# Altium schematic sheet Power Management.SchDoc("Power Management")
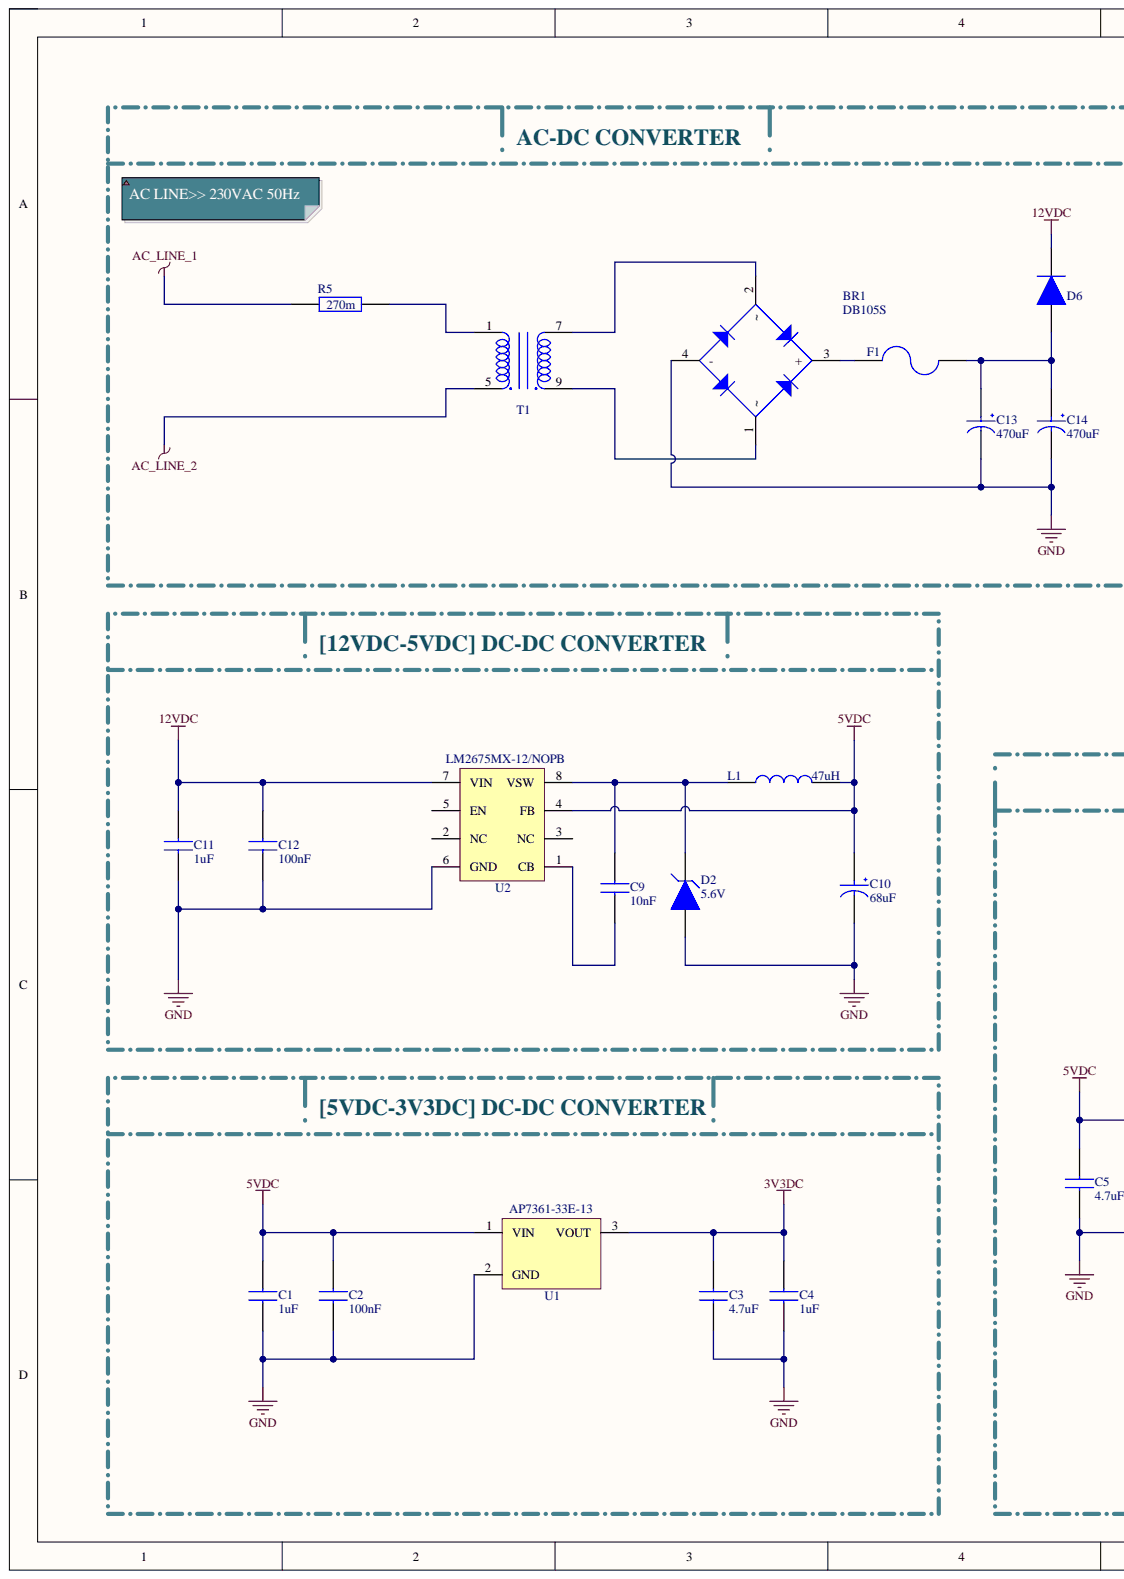
[diagram p0: Power Management.SchDoc, left]
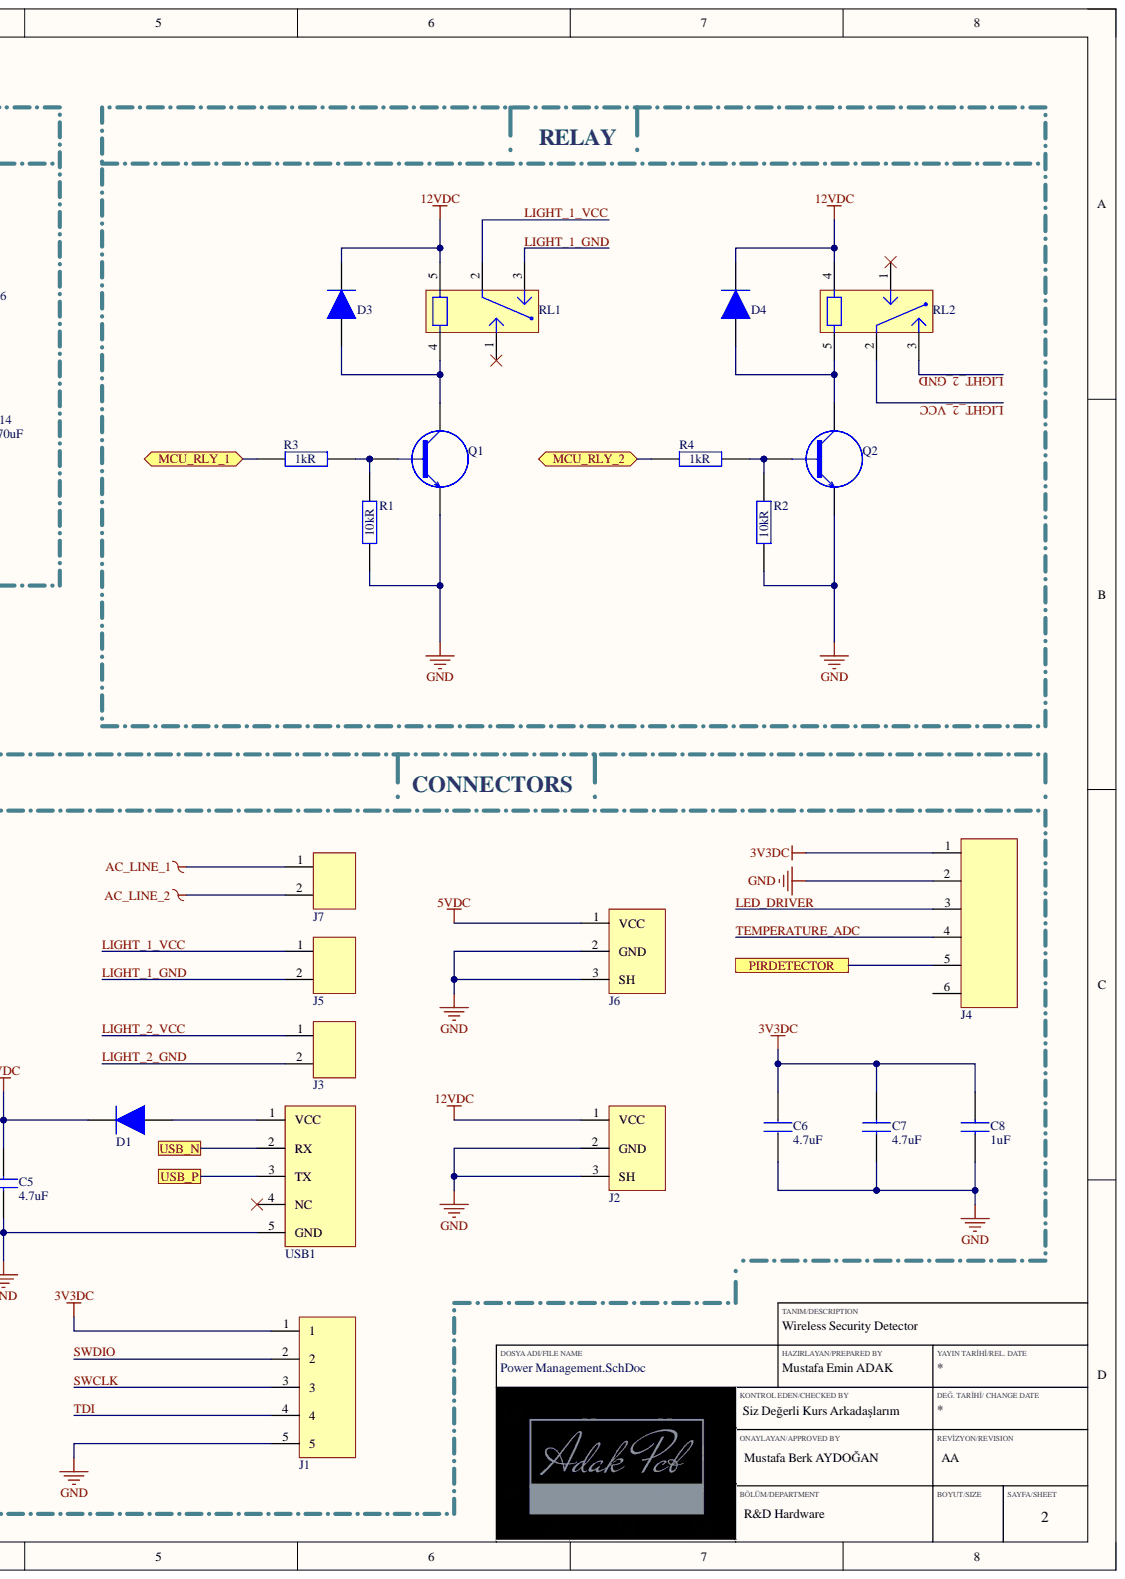
[diagram p1: Power Management.SchDoc, right]

## Parts
BR1  DB105S  [DB105S]
C1  Capacitance: 1uF  [CAP_0805]  (hidden comment: CL21B105KBFNNNE)
C2  Capacitance: 100nF  [CAP_0603]  (hidden comment: C0603C104K4RAC7867)
C3  Capacitance: 4.7uF  [CAP_0805]  (hidden comment: CL21A475KAQNNNE)
C4  Capacitance: 1uF  [CAP_0805]  (hidden comment: CL21B105KBFNNNE)
C5  Capacitance: 4.7uF  [CAP_0805]  (hidden comment: CL21A475KAQNNNE)
C6  Capacitance: 4.7uF  [CAP_0805]  (hidden comment: CL21A475KAQNNNE)
C7  Capacitance: 4.7uF  [CAP_0805]  (hidden comment: CL21A475KAQNNNE)
C8  Capacitance: 1uF  [CAP_0805]  (hidden comment: CL21B105KBFNNNE)
C9  Capacitance: 10nF  [CAP_0603]  (hidden comment: 0603B103K160CT)
C10  Capacitance: 68uF  [CAP_EEV/SERIES]  (hidden comment: EEV686M035A9HAA)
C11  Capacitance: 1uF  [CAP_0805]  (hidden comment: CL21B105KBFNNNE)
C12  Capacitance: 100nF  [CAP_0805]  (hidden comment: CL21B104KBCNNNC)
C13  Capacitance: 470uF  [CAP_RADIAL_D10_P5]  (hidden comment: ESH477M050AH4AA)
C14  Capacitance: 470uF  [CAP_RADIAL_D10_P5]  (hidden comment: ESH477M050AH4AA)
D1  1N4148WS  [SOD323F]  (value not drawn)
D2  Voltage Rating (DC): 5.6V  [SMB]  (hidden comment: 1SMB5919BT3G)
D3  1N4148WS  [SOD323F]  (value not drawn)
D4  1N4148WS  [SOD323F]  (value not drawn)
D6  1N4148WS  [SOD323F]  (value not drawn)
F1  3413.0216.22  [3413.0216.22]  (value not drawn)
J1  SMH-105-02-L-S  [PINHEADER1X5_RA]  (value not drawn)
J2  PJ-037AH-SMT-TR  [JACK]  (value not drawn)
J3  TBP01R1-508-02BE  [TBP01R1-508]  (value not drawn)
J4  64800611622  [64800611622]  (value not drawn)
J5  TBP01R1-508-02BE  [TBP01R1-508]  (value not drawn)
J6  PJ-037AH-SMT-TR  [JACK]  (value not drawn)
J7  TBP01R1-508-02BE  [TBP01R1-508]  (value not drawn)
L1  Inductance: 47uH  [IND_1212]  (hidden comment: 78438335470)
Q1  MMBT3904-7-F  [SOT-23F]  (value not drawn)
Q2  MMBT3904-7-F  [SOT-23F]  (value not drawn)
R1  Resistance: 10kR  [RES_0603]  (hidden comment: RC0603FR-0710KL)
R2  Resistance: 10kR  [RES_0603]  (hidden comment: RC0603FR-0710KL)
R3  Resistance: 1kR  [RES_0603]  (hidden comment: RC0603FR-071KL)
R4  Resistance: 1kR  [RES_0603]  (hidden comment: RC0603FR-071KL)
R5  Resistance: 270m  [RES_AXIAL_W]  (hidden comment: 5WD27)
RL1  ALQ112  [ALQ112]  (value not drawn)
RL2  ALQ112  [ALQ112]  (value not drawn)
T1  BV302S12010-ZU  [BV302S]  (value not drawn)
U1  AP7361-33E-13  [SOT223]
U2  LM2675MX-12/NOPB  [SOIC8]
USB1  10118192-0001LF  [MICRO_B_USB]  (value not drawn)

## Ports
MCU_RLY_1, MCU_RLY_2, PIRDETECTOR, USB_N, USB_P

## Nets
3V3DC: C3.2 C4.2 C6.2 C7.2 C8.2 J1.1 J4.1 U1.3
5VDC: C1.2 C2.2 C5.2 C10.1 D1.1 J6.1 L1.2 U1.1 U2.4(FB)
12VDC: C11.2 C12.2 D3.1 D4.1 D6.1 J2.1 RL1.5 RL2.4 U2.7(VIN)
AC_LINE_1: J7.1 R5.1
AC_LINE_2: J7.2 T1.5
GND: BR1.4(-) C1.1 C2.1 C3.1 C4.1 C5.1 C6.1 C7.1 C8.1 C10.2 C11.1 C12.1 C13.2 C14.2 D2.C J1.5 J2.2 J2.3 J4.2 J6.2 J6.3 Q1.2 Q2.2 R1.1 R2.1 U1.2 U2.6(GND) USB1.5(GND)
LED_DRIVER: J4.3
LIGHT_1_GND: J5.2 RL1.3
LIGHT_1_VCC: J5.1 RL1.2
LIGHT_2_GND: J3.2 RL2.3
LIGHT_2_VCC: J3.1 RL2.2
MCU_RLY_1: R3.1 ; port MCU_RLY_1
MCU_RLY_2: R4.1 ; port MCU_RLY_2
PIRDETECTOR: J4.5 ; port PIRDETECTOR
SWCLK: J1.3
SWDIO: J1.2
TDI: J1.4
TEMPERATURE_ADC: J4.4
USB_N: USB1.2(RX) ; port USB_N
USB_P: USB1.3(TX) ; port USB_P
NetBR1_1: BR1.1(~) T1.9
NetBR1_2: BR1.2(~) T1.7
NetBR1_3: BR1.3(+) F1.1
NetC9_1: C9.1 U2.1(CB)
NetC9_2: C9.2 D2.A L1.1 U2.8(VSW)
NetC13_1: C13.1 C14.1 D6.2 F1.2
NetD1_2: D1.2 USB1.1(VCC)
NetD3_2: D3.2 Q1.3 RL1.4
NetD4_2: D4.2 Q2.3 RL2.5
NetQ1_1: Q1.1 R1.2 R3.2
NetQ2_1: Q2.1 R2.2 R4.2
NetR5_2: R5.2 T1.1

Not connected: J4 6; RL1 1; RL2 1; U2 2(NC) 3(NC) 5(EN); USB1 4(NC)

## Library data of the parts
BR1 = DB105S: |Bridge Rectifier Single Phase Standard 600 V Surface Mount DB-S; Manufacturer: SMC Diode Solutions; Current(MAX): 1A; Designed by: ADAK; Voltage: 600V; Case/Package: DB105S; Category: Uncategorized; Component Kind: Standard; Library Name: Udemy_ADAK_Library1.IntLib; Manufacturer Part Number: DB105S; Phase Type: Single Phase; Pricing 1: 1=0,42000, 10=0,31400, 100=0,19570, 500=0,13390 (USD); RoHS: No; Stock 1: 23239; Supplier 1: Digi-Key; Supplier Part Number 1: 1655-DB105SCT-ND
C1 C4 C8 C11 = CAP CER 1uF 0805: |CAP CER 1UF %10 0805 SMD X7R; Manufacturer: Samsung; Designed by: ADAK; Tolerance: 10%; Voltage: 50V; Case Code (Metric): 2012; Case Code (Imperial): 0805; Case/Package: 0805; Category: Ceramic Capacitors; Component Kind: Standard; ComponentLink1Description: https://datasheet.ciiva.com/25800/cl21b105kbfnnne-25800291.pdf?src-supplier=Digi-Key; ComponentLink1URL: https://datasheet.ciiva.com/25800/cl21b105kbfnnne-25800291.pdf?src-supplier=Digi-Key; Construction: Flat; Depth: 1.25mm; Dielectric: X7R; Height: 1.25mm; Length: 2mm; Library Name: Udemy_ADAK_Library1.IntLib; Manufacturer Part Number: CL21B105KBFNNNE; Max Operating Temperature: 125°C; Min Operating Temperature: -55°C; Mount: Surface Mount; Package Quantity: 1; Packaging: Tape and Reel; Pricing 1: 1=0,11000, 10=0,07900, 50=0,04500, 100=0,03730, 500=0,02616, 1000=0,02165 (USD); Radiation Hardening: No; RoHS: Yes; RoHS Compliant: Yes; Series: CL; Stock 1: 1344581; Supplier 1: Digi-Key; Supplier Part Number 1: 1276-1029-6-ND; Thickness: 0.053inch; Voltage Rating: 50V; Width: 0.049inch
C2 = CAP CER 100nF 0603: |CAP CER 100nF (0.1UF) %10 0603 SMD; Manufacturer: KEMET; Manufacturer Part Number: C0603C104K4RACTU; Designed by: ADAK; Dielectric: X7R; Dielectric Material: Ceramic; Dissipation Factor: 3.5%; Tolerance: 10%; Voltage: 16V; Case Code (Metric): 1608; Case Code (Imperial): 0603; Case/Package: 0603; Category: Ceramic Capacitors; Component Kind: Standard; ComponentLink1Description: https://datasheet.ciiva.com/22749/kem-c1002-x7r-smd-22749657.pdf?src-supplier=Element14; ComponentLink1URL: https://datasheet.ciiva.com/22749/kem-c1002-x7r-smd-22749657.pdf?src-supplier=Element14; ComponentLink2Description: https://datasheet.ciiva.com/14589/70095383-14589014.pdf?src-supplier=Allied+Electronics; ComponentLink2URL: https://datasheet.ciiva.com/14589/70095383-14589014.pdf?src-supplier=Allied+Electronics; ComponentLink3Description: https://datasheet.ciiva.com/5413/kem-c1002-x7r-smd-5413791.pdf?src-supplier=Mouser; ComponentLink3URL: https://datasheet.ciiva.com/5413/kem-c1002-x7r-smd-5413791.pdf?src-supplier=Mouser; ComponentLink4Description: https://datasheet.ciiva.com/18192/getdatasheetpartid-330584-18192695.pdf?src-supplier=Verical; ComponentLink4URL: https://datasheet.ciiva.com/18192/getdatasheetpartid-330584-18192695.pdf?src-supplier=Verical; Construction: Flat; Contact Plating: Tin,Matte; Depth: 0.8mm; Height: 0.8mm; Lead Pitch: 0.7mm; Length: 1.6mm; Library Name: Udemy_ADAK_Library1.IntLib; Max Operating Temperature: 125°C; Min Operating Temperature: -55°C; Mount: Surface Mount,PCB; Number of Pins: 2; Package Quantity: 4000; Packaging: Tape and Reel; Pricing 1: 1=0,10000, 10=0,07200, 50=0,03940, 100=0,03220, 500=0,02298, 1000=0,01805 (USD); Radiation Hardening: No; Reach SVHC Compliant: Yes; RoHS: Yes; RoHS Compliant: Yes; Series: SMD Comm X7R; Supplier 1: Digi-Key; Supplier Part Number 1: 399-C0603C104K4RAC7867DKR-ND; Termination: SMD/SMT; Thickness: 0.034inch; Voltage Rating: 16V; Voltage Rating (DC): 16V; Weight: 7.1E-05oz; Width: 0.8mm
C3 C5-C7 = CAP CER 4.7uF 0805: |CAP CER 4.7UF %10 0805 SMD X5R; Manufacturer: Samsung; Designed by: ADAK; Tolerance: 10%; Voltage Rating: 25V; Case Code (Metric): 2012; Case Code (Imperial): 0805; Case/Package: 0805; Category: Ceramic Capacitors; Component Kind: Standard; ComponentLink1Description: https://datasheet.ciiva.com/25798/cl21a475kaqnnne-25798882.pdf?src-supplier=Digi-Key; ComponentLink1URL: https://datasheet.ciiva.com/25798/cl21a475kaqnnne-25798882.pdf?src-supplier=Digi-Key; Construction: Flat; Depth: 1.25mm; Dielectric: X5R; Height: 1.25mm; Lead Free: Lead Free; Length: 2mm; Library Name: Udemy_ADAK_Library1.IntLib; Manufacturer Part Number: CL21A475KAQNNNE; Max Operating Temperature: 85°C; Min Operating Temperature: -55°C; Mount: Surface Mount; Package Quantity: 1; Packaging: Tape and Reel; Pricing 1: 1=0,10000, 10=0,07300, 50=0,04140, 100=0,03440, 500=0,02408, 1000=0,01994 (USD); Radiation Hardening: No; RoHS: Yes; RoHS Compliant: Yes; Series: CL; Stock 1: 4312449; Supplier 1: Digi-Key; Supplier Part Number 1: 1276-1244-1-ND; Thickness: 0.055inch; Voltage Rating (DC): 25V; Width: 0.049inch
C9 = CAP CER 10nF 0603: |CAP CER 10nF %10 0603 SMD X7R; Manufacturer: Walsin Technologies; Designed by: ADAK; Tolerance: 10%; Voltage Rating: 16V; Case Code (Metric): 1608; Case Code (Imperial): 0603; Case/Package: 0603; Category: Ceramic Capacitors; Component Kind: Standard; ComponentLink1Description: http://www.farnell.com/datasheets/1958513.pdf?src-supplier=Farnell; ComponentLink1URL: http://www.farnell.com/datasheets/1958513.pdf?src-supplier=Farnell; ComponentLink2Description: http://www.farnell.com/datasheets/1958513.pdf?src-supplier=Element14; ComponentLink2URL: http://www.farnell.com/datasheets/1958513.pdf?src-supplier=Element14; ComponentLink3Description: http://www.passivecomponent.com/wp-content/uploads/2018/10/MLCC.pdf?src-supplier=Digi-Key; ComponentLink3URL: http://www.passivecomponent.com/wp-content/uploads/2018/10/MLCC.pdf?src-supplier=Digi-Key; Depth: 0.8mm; Dielectric: X7R; Length: 1.6mm; Library Name: Udemy_ADAK_Library1.IntLib; Manufacturer Part Number: 0603B103K160CT; Max Operating Temperature: 125°C; Min Operating Temperature: -55°C; Packaging: Tape and Reel; Pricing 1: 1=0,10000, 10=0,03300, 50=0,01820, 100=0,01480, 500=0,01060, 1000=0,00833 (USD); RoHS: Yes; RoHS Compliant: Yes; Stock 1: 148568; Supplier 1: Digi-Key; Supplier Part Number 1: 1292-1384-1-ND; Voltage Rating (DC): 16V
C10 = CAP ALU 68uF: |CAP ALUM 68UF 20% 35V SMD; Manufacturer: KEMET; Designed by: ADAK; Life (Hours): 2000Hour; Ripple Current: 196mA; Impedance: 340mR; Case/Package: Radial; Category: Aluminum Electrolytic Capacitors; Component Kind: Standard; ComponentLink1Description: https://datasheet.ciiva.com/5365/kem-a4002-eev-535332-5365784.pdf?src-supplier=Mouser; ComponentLink1URL: https://datasheet.ciiva.com/5365/kem-a4002-eev-535332-5365784.pdf?src-supplier=Mouser; Height - Seated (Max): 0.315inch; Length: 7.7mm; Library Name: Udemy_ADAK_Library1.IntLib; Manufacturer Part Number: EEV686M035A9HAA; Max Operating Temperature: 105°C; Min Operating Temperature: -40°C; Mount: Surface Mount; Package Quantity: 1; Packaging: Tape and Reel; Pricing 1: 1=0,67000, 10=0,47200, 50=0,35680, 100=0,31080, 500=0,23024 (USD); RoHS: Yes; RoHS Compliant: Yes; Series: EEV; Stock 1: 3109; Supplier 1: Digi-Key; Supplier Part Number 1: 399-6722-1-ND; Termination: SMD/SMT; Tolerance: 20%; Voltage Rating: 35V; Voltage Rating (DC): 35V
C12 = CAP CER 100nF 0805: |CAP CER 0.1UF (100nF) %10 0805 SMD X7R; Manufacturer: Samsung; Designed by: ADAK; Material: Ceramic; Tolerance: 10%; Voltage: 50V; Case Code (Metric): 2012; Case Code (Imperial): 0805; Case/Package: 0805; Category: Ceramic Capacitors; Component Kind: Standard; ComponentLink1Description: https://datasheet.ciiva.com/25710/cl21b104kbcnnnc-25710182.pdf?src-supplier=Digi-Key; ComponentLink1URL: https://datasheet.ciiva.com/25710/cl21b104kbcnnnc-25710182.pdf?src-supplier=Digi-Key; ComponentLink2Description: https://datasheet.ciiva.com/29199/454152-da-01-en-vs-kondensator-0805-29199814.pdf?src-supplier=Conrad; ComponentLink2URL: https://datasheet.ciiva.com/29199/454152-da-01-en-vs-kondensator-0805-29199814.pdf?src-supplier=Conrad; Construction: Flat; Contact Plating: Tin; Depth: 1.25mm; Dielectric: X7R; Height: 0.85mm; Lead Free: Lead Free; Length: 2mm; Library Name: Udemy_ADAK_Library1.IntLib; Manufacturer Part Number: CL21B104KBCNNNC; Max Operating Temperature: 125°C; Min Operating Temperature: -55°C; Mount: Surface Mount; Packaging: Tape and Reel; Pricing 1: 1=0,10000, 10=0,04400, 50=0,02400, 100=0,01960, 500=0,01404, 1000=0,01103 (USD); Radiation Hardening: No; RoHS: Yes; RoHS Compliant: Yes; Series: CL; Stock 1: 3413319; Supplier 1: Digi-Key; Supplier Part Number 1: 1276-1003-1-ND; Thickness: 0.037inch; Voltage Rating: 50V; Voltage Rating (DC): 50V; Width: 0.049inch
C13 C14 = CAP ALU ELEC 470uF 50V: |CAP (AL) 470UF 20% 50V RADIAL; Manufacturer: KEMET; Designed by: ADAK; Life (Hours): 2000Hour; Polarity: Polar; Case/Package: Radial; Component Kind: Standard; ComponentLink1Description: https://api.kemet.com/component-edge/download/datasheet/ESH477M050AH4AA.pdf?src-supplier=Digi-Key; ComponentLink1URL: https://api.kemet.com/component-edge/download/datasheet/ESH477M050AH4AA.pdf?src-supplier=Digi-Key; Library Name: Udemy_ADAK_Library1.IntLib; Manufacturer Part Number: ESH477M050AH4AA; Max Operating Temperature: 105°C; Min Operating Temperature: -40°C; Mount: Through Hole; Packaging: Bulk; Pricing 1: 1=0,73000, 10=0,51800, 50=0,39180, 100=0,34120, 500=0,25276, 1000=0,21485, 2500=0,20222, 5000=0,18958 (USD); RoHS: Yes; RoHS Compliant: No; Stock 1: 11912; Supplier 1: Digi-Key; Supplier Part Number 1: 399-15683-ND; Tolerance: 20%; Voltage Rating: 50V
D1 D3 D4 D6 = 1N4148WS: |Diode 75 V 150mA Surface Mount SOD-323F; Manufacturer: ON Semiconductor / Fairchild; Designed by: ADAK; Element Configuration: Single; Forward Voltage: 1V; Max Forward Surge Current (Ifsm): 2A; Max Repetitive Reverse Voltage (Vrrm): 75V; Max Reverse Current: 5uA; Power Dissipation: 200mW; Recovery Time: 4ns; Average Rectified Current: 150mA; Category: Rectifier Diodes; Component Kind: Standard; ComponentLink1Description: https://datasheet.ciiva.com/30479/0900766b810e1cd3-30479206.pdf?src-supplier=Rs; ComponentLink1URL: https://datasheet.ciiva.com/30479/0900766b810e1cd3-30479206.pdf?src-supplier=Rs; ComponentLink2Description: https://datasheet.ciiva.com/15208/getdatasheetpartid-127819-15208358.pdf?src-supplier=Verical; ComponentLink2URL: https://datasheet.ciiva.com/15208/getdatasheetpartid-127819-15208358.pdf?src-supplier=Verical; ComponentLink3Description: https://datasheet.ciiva.com/8300/1n914bws-8300360.pdf?src-supplier=Avnet; ComponentLink3URL: https://datasheet.ciiva.com/8300/1n914bws-8300360.pdf?src-supplier=Avnet; ComponentLink4Description: https://datasheet.ciiva.com/910/1n4148ws-910381.pdf?src-supplier=Digi-Key; ComponentLink4URL: https://datasheet.ciiva.com/910/1n4148ws-910381.pdf?src-supplier=Digi-Key; ComponentLink5Description: https://datasheet.ciiva.com/910/1n4148ws-910382.pdf?src-supplier=Digi-Key; ComponentLink5URL: https://datasheet.ciiva.com/910/1n4148ws-910382.pdf?src-supplier=Digi-Key; ComponentLink6Description: https://datasheet.ciiva.com/910/1n4148ws-910380.pdf?src-supplier=Digi-Key; ComponentLink6URL: https://datasheet.ciiva.com/910/1n4148ws-910380.pdf?src-supplier=Digi-Key; Contact Plating: Tin,Matte; Forward Current: 150mA; Height: 1mm; Lead Free: Lead Free; Length: 1.8mm; Library Name: Udemy_ADAK_Library1.IntLib; Manufacturer Part Number: 1N4148WS; Max Operating Temperature: 150°C; Max Reverse Voltage (DC): 75V; Min Operating Temperature: -55°C; Mount: Surface Mount; Number of Pins: 2; Package Quantity: 1; Packaging: Tape and Reel; Pricing 1: 1=0,21000, 10=0,19200, 100=0,10460, 500=0,06436, 1000=0,04388 (USD); Radiation Hardening: No; Reach SVHC Compliant: Yes; Reverse Recovery Time: 4ns; Reverse Voltage: 75V; RoHS: Yes; RoHS Compliant: Yes; Series: -; Stock 1: 1945488; Supplier 1: Digi-Key; Supplier Part Number 1: 1N4148WSFSCT-ND; Weight: 0.0276g; Width: 1.35mm
D2 = 1SMB5919BT3G: |Zener Diode 5.6 V 3 W ±5% Surface Mount SMB; Manufacturer: ON Semiconductor; Breakdown Voltage: 5.88V; Designed by: ADAK; Element Configuration: Single; ESD Protection: Yes; Forward Voltage: 1.5V; Material: Plastic; Max Power Dissipation: 3W; Max Reverse Current: 5uA; Power Dissipation: 550mW; Test Current: 66.9mA; Zener Current: 267mA; Impedance: 2R; Case/Package: SMB; Category: Zener Diodes; Component Kind: Standard; ComponentLink1Description: https://datasheet.ciiva.com/27747/1308331-27747654.pdf?src-supplier=Jameco; ComponentLink1URL: https://datasheet.ciiva.com/27747/1308331-27747654.pdf?src-supplier=Jameco; ComponentLink2Description: https://datasheet.ciiva.com/1182/1smb5913bt3-d-1182657.pdf?src-supplier=Element14; ComponentLink2URL: https://datasheet.ciiva.com/1182/1smb5913bt3-d-1182657.pdf?src-supplier=Element14; ComponentLink3Description: https://datasheet.ciiva.com/1182/1smb5913bt3-d-1182658.pdf?src-supplier=Element14; ComponentLink3URL: https://datasheet.ciiva.com/1182/1smb5913bt3-d-1182658.pdf?src-supplier=Element14; ComponentLink4Description: https://datasheet.ciiva.com/16311/1smb5913bt3-d-16311847.pdf?src-supplier=Future+Electronics; ComponentLink4URL: https://datasheet.ciiva.com/16311/1smb5913bt3-d-16311847.pdf?src-supplier=Future+Electronics; ComponentLink5Description: https://datasheet.ciiva.com/15198/getdatasheetpartid-311040-15198695.pdf?src-supplier=Verical; ComponentLink5URL: https://datasheet.ciiva.com/15198/getdatasheetpartid-311040-15198695.pdf?src-supplier=Verical; ComponentLink6Description: https://datasheet.ciiva.com/895/1smb5913bt3-d-895204.pdf?src-supplier=Digi-Key; ComponentLink6URL: https://datasheet.ciiva.com/895/1smb5913bt3-d-895204.pdf?src-supplier=Digi-Key; ComponentLink7Description: https://datasheet.ciiva.com/895/1smb5913bt3-d-895205.pdf?src-supplier=Digi-Key; ComponentLink7URL: https://datasheet.ciiva.com/895/1smb5913bt3-d-895205.pdf?src-supplier=Digi-Key; ComponentLink8Description: https://datasheet.ciiva.com/895/1smb5913bt3-d-895203.pdf?src-supplier=Digi-Key; ComponentLink8URL: https://datasheet.ciiva.com/895/1smb5913bt3-d-895203.pdf?src-supplier=Digi-Key; Contact Plating: Tin,Matte; Halogen Free: Halogen Free; Height: 2.13mm; Lead Free: Lead Free; Length: 4.32mm; Library Name: Udemy_ADAK_Library1.IntLib; Manufacturer Part Number: 1SMB5919BT3G; Max Operating Temperature: 150°C; Max Reverse Leakage Current: 5uA; Min Operating Temperature: -65°C; Number of Pins: 2; Package Quantity: 2500; Packaging: Tape and Reel; Power Rating: 3W; Pricing 1: 1=0,39000, 10=0,31600, 100=0,21540, 500=0,16154, 1000=0,12116 (USD); Radiation Hardening: No; Reach SVHC Compliant: Yes; RoHS: Yes; RoHS Compliant: Yes; Series: -; Supplier 1: Digi-Key; Supplier Part Number 1: 1SMB5919BT3GOSCT-ND; Tolerance: 5%; Voltage Tolerance: 5%; Width: 3.56mm; Working Voltage: 5.6V; Zener Voltage: 5.6V
F1 = FUSE 3413.0216.22: |FUSE Chip Fast Acting 1A 32V SMD Solder Pad 1206; Manufacturer: Schurter; Approvals: VDE,cURus; Body Material: Glass,Epoxy; DC Cold Resistance: 94.2mR; Designed by: ADAK; Fuse Type: Board Mount (Cartridge Style Excluded); Max Voltage Rating (DC): 63V; Case Code (Metric): 3216; Case Code (Imperial): 1206; Case/Package: 1206; Category: Fuses; Component Kind: Standard; ComponentLink1Description: https://datasheet.ciiva.com/301/typ-usi-1206-301440.pdf?src-supplier=Digi-Key; ComponentLink1URL: https://datasheet.ciiva.com/301/typ-usi-1206-301440.pdf?src-supplier=Digi-Key; ComponentLink2Description: https://datasheet.ciiva.com/14541/70160006-14541114.pdf?src-supplier=Allied+Electronics; ComponentLink2URL: https://datasheet.ciiva.com/14541/70160006-14541114.pdf?src-supplier=Allied+Electronics; ComponentLink3Description: https://datasheet.ciiva.com/301/typ-usi-1206-301439.pdf?src-supplier=Digi-Key; ComponentLink3URL: https://datasheet.ciiva.com/301/typ-usi-1206-301439.pdf?src-supplier=Digi-Key; ComponentLink4Description: https://datasheet.ciiva.com/301/typ-usi-1206-301441.pdf?src-supplier=Digi-Key; ComponentLink4URL: https://datasheet.ciiva.com/301/typ-usi-1206-301441.pdf?src-supplier=Digi-Key; ComponentLink5Description: https://datasheet.ciiva.com/8264/typ-usi-1206-8264583.pdf?src-supplier=Avnet; ComponentLink5URL: https://datasheet.ciiva.com/8264/typ-usi-1206-8264583.pdf?src-supplier=Avnet; ComponentLink6Description: https://datasheet.ciiva.com/14102/qousi1206-data-e-14102903.pdf?src-supplier=Distrelec; ComponentLink6URL: https://datasheet.ciiva.com/14102/qousi1206-data-e-14102903.pdf?src-supplier=Distrelec; ComponentLink7Description: https://datasheet.ciiva.com/16316/typ-usi-1206-16316588.pdf?src-supplier=Future+Electronics; ComponentLink7URL: https://datasheet.ciiva.com/16316/typ-usi-1206-16316588.pdf?src-supplier=Future+Electronics; Contact Plating: Gold; Current Rating: 1A; Depth: 1.6mm; Height: 0.6mm; Housing Material: Glass; Lead Free: Lead Free; Length: 3.2mm; Library Name: Udemy_ADAK_Library1.IntLib; Manufacturer Part Number: 3413.0216.22; Max Operating Temperature: 90°C; Max Voltage Rating (AC): 32V; Min Operating Temperature: -55°C; Mount: Surface Mount,PCB; Number of Pins: 2; Package Quantity: 1000; Packaging: Tape and Reel; Pricing 1: 1=0,67000, 50=0,52400, 100=0,39700, 500=0,32624 (USD); Radiation Hardening: No; RoHS: Yes; RoHS Compliant: Yes; Series: USI 1206; Stock 1: 6807; Supplier 1: Digi-Key; Supplier Part Number 1: 486-1144-1-ND; Terminal Material: Tin; Termination: SMD/SMT; Voltage Rating: 32V; Voltage Rating (AC): 32V; Voltage Rating (DC): 63V; Weight: 0.000212oz; Width: 1.6mm; Working Voltage: 32V
J1 = SMH-105-02-L-S: |Connector-5 Position Receptacle 0.100" (2.54mm) Surface Mount, Right Angle Gold; Manufacturer: Samtec; Contact Resistance: 10mR; Designed by: ADAK; Number of Contacts: 5; Category: Connectors; Component Kind: Standard; ComponentLink1Description: http://www.farnell.com/datasheets/1927708.pdf?_ga=2.237598468.569325269.1503285096-1413671594.1503025027&src-supplier=Farnell; ComponentLink1URL: http://www.farnell.com/datasheets/1927708.pdf?_ga=2.237598468.569325269.1503285096-1413671594.1503025027&src-supplier=Farnell; ComponentLink2Description: http://www.samtec.com/documents/webfiles/pdf/SMH.PDF?src-supplier=Newark; ComponentLink2URL: http://www.samtec.com/documents/webfiles/pdf/SMH.PDF?src-supplier=Newark; ComponentLink3Description: http://www.samtec.com/documents/webfiles/pdf/SMH.PDF?src-supplier=Avnet; ComponentLink3URL: http://www.samtec.com/documents/webfiles/pdf/SMH.PDF?src-supplier=Avnet; ComponentLink4Description: http://suddendocs.samtec.com/catalog_english/smh.pdf?src-supplier=Digi-Key; ComponentLink4URL: http://suddendocs.samtec.com/catalog_english/smh.pdf?src-supplier=Digi-Key; Connector Type: Receptacle; Contact Finish - Mating: GOLD; Contact Material: Bronze; Contact Plating: Gold; Contact Shape: Square; Contact Type: Forked; Depth: 10.8mm; Fastening Type: Pull; Flammability Rating: UL94 V-0; Gender: Receptacle; Housing Color: Black; Housing Material: Polymer; Length: 13.21mm; Library Name: Udemy_ADAK_Library1.IntLib; Manufacturer Part Number: SMH-105-02-L-S; Max Operating Temperature: 125°C; Min Operating Temperature: -55°C; Number of Positions: 5; Number of Rows: 1; Orientation: Horizontal; Packaging: Rail/Tube; Pitch: 2.54mm; Pricing 1: 1=2,18000, 10=1,89900, 100=1,69680, 500=1,41400, 1000=1,21200, 5000=1,07464 (USD); Radiation Hardening: No; Reach SVHC Compliant: Yes; RoHS: Yes; RoHS Compliant: Yes; Series: SMH; Stock 1: 206; Supplier 1: Digi-Key; Supplier Part Number 1: SAM11813-ND; Termination: Solder; Insulation Color: Black; Insulation Material: Polymer
J2 J6 = PJ-037AH-SMT-TR: |Power Barrel Connector Jack 2.10mm ID (0.083"), 5.50mm OD (0.217") Surface Mount, Right Angle; Through Hole; Manufacturer: CUI; Current Rating: 5A; Designed by: ADAK; Gender: Male; Number of Conductors: 2; Shielding: Unshielded; Voltage Rating: 24V; Insulation Resistance: 100MR; Case/Package: SMD/SMT; Category: Uncategorized; Color: Black; Component Kind: Standard; ComponentLink1Description: http://www.mouser.com/ds/2/670/pj-037ah-smt-516065.pdf?src-supplier=Mouser; ComponentLink1URL: http://www.mouser.com/ds/2/670/pj-037ah-smt-516065.pdf?src-supplier=Mouser; ComponentLink2Description: https://www.cui.com/product/resource/digikeypdf/pj-037ah-smt-tr.pdf?src-supplier=Digi-Key; ComponentLink2URL: https://www.cui.com/product/resource/digikeypdf/pj-037ah-smt-tr.pdf?src-supplier=Digi-Key; ComponentLink3Description: http://www.cui.com/product/resource/digikeypdf/pj-037ah-smt.pdf?src-supplier=Digi-Key; ComponentLink3URL: http://www.cui.com/product/resource/digikeypdf/pj-037ah-smt.pdf?src-supplier=Digi-Key; Connector Type: Jack; Contact Gender: Male; Contact Material: Copper; Contact Plating: Nickel; Flammability Rating: UL94 V-0; Housing Color: Black; Housing Material: Thermoplastic; Library Name: Udemy_ADAK_Library1.IntLib; Manufacturer Part Number: PJ-037AH-SMT-TR; Max Operating Temperature: 85°C; Min Operating Temperature: -25°C; Mount: Surface Mount,Through Hole; Orientation: Horizontal; Package Quantity: 200; Packaging: Tape and Reel; Pricing 1: 1=1,510000, 10=1,317000, 25=1,261200, 50=1,233200, 100=1,177200 (USD); RoHS: Yes; Stock 1: 93; Supplier 1: Digi-Key; Supplier Part Number 1: CP-037AHPJCT-ND; Termination: Solder
J3 J5 J7 = TBP01R1-508-02BE: |Header 2 Position Terminal Block, Male Pins, Shrouded (4 Side) 0.200" (5.08mm) 90°, Right Angle Through Hole; Manufacturer: CUI Devices; Designed by: ADAK; Category: Terminal Blocks; Component Kind: Standard; Library Name: Udemy_ADAK_Library1.IntLib; Manufacturer Part Number: TBP01R1-508-02BE; Pricing 1: 1=0,56000, 10=0,29600, 25=0,20960, 50=0,17840, 100=0,17220, 250=0,14560, 500=0,13464, 1000=0,11898, 5000=0,09080 (USD); RoHS: Yes; Stock 1: 141024; Supplier 1: Digi-Key; Supplier Part Number 1: 102-6406-ND
J4 = 64800611622_CONN_6P: |Connector (1.50mm) 6 Pin Header Through Hole; Manufacturer: Wurth Electronics; Designed by: ADAK; Component Kind: Standard; Library Name: Udemy_ADAK_Library1.IntLib; Manufacturer Part Number: 64800611622; Pricing 1: 1=0,42000, 50=0,40600, 100=0,38400, 250=0,36100, 500=0,33900 (USD); RoHS: No; Stock 1: 979; Supplier 1: Digi-Key; Supplier Part Number 1: 732-64800611622-ND
L1 = IND 47uH: |FIXED IND 47UH 390MA 2.3 OHM SMD; Manufacturer: Wurth Electronics; Core Material: Ferrite; DC Resistance (DCR): 2.3R; Designed by: ADAK; Saturation Current: 1.18A; Self Resonant Frequency: 8MHz; Shielding: Shielded; Test Frequency: 100kHz; Tolerance: 30%; Case Code (Metric): 3030; Case Code (Imperial): 1212; Case/Package: 1212; Category: Fixed Inductors; Component Kind: Standard; ComponentLink1Description: https://media.digikey.com/pdf/Data%20Sheets/Wurth%20Electronics%20PDFs/Q+_Products_Flyer.pdf?src-supplier=Digi-Key; ComponentLink1URL: https://media.digikey.com/pdf/Data%20Sheets/Wurth%20Electronics%20PDFs/Q+_Products_Flyer.pdf?src-supplier=Digi-Key; Current Rating: 390mA; Height - Seated (Max): 0.059inch; Length: 0.118inch; Library Name: Udemy_ADAK_Library1.IntLib; Manufacturer Part Number: 78438335470; Max Operating Temperature: 125°C; Min Operating Temperature: -40°C; Mount: Surface Mount; Packaging: Tape and Reel; Pricing 1: 1=2,11000, 50=1,97000, 250=1,80300, 500=1,67200 (USD); Ratings: AEC-Q200; Resistor Type: Wirewound; RoHS: Yes; RoHS Compliant: Yes; Stock 1: 15105; Supplier 1: Digi-Key; Supplier Part Number 1: 732-6947-1-ND; Width: 0.118inch
Q1 Q2 = MMBT3904-7-F: |Bipolar (BJT) Transistor NPN 40 V 200 mA 300MHz 300 mW Surface Mount SOT-23-3; Manufacturer: Diodes Zetex; Collector Base Voltage (VCBO): 60V; Collector Emitter Breakdown Voltage: 40V; Collector Emitter Saturation Voltage: 300mV; Continuous Collector Current: 200mA; Designed by: ADAK; Element Configuration: Single; Emitter Base Voltage (VEBO): 6V; hFE Min: 100; Max Power Dissipation: 300mW; Number of Elements: 1; Power Dissipation: 350mW; Case/Package: SOT-23; Category: BJTs; Collector Emitter Voltage (VCEO): 40V; Collector-emitter Voltage-Max: 300mV; Component Kind: Standard; ComponentLink1Description: https://datasheet.ciiva.com/11201/ds30036-11201778.pdf?src-supplier=Avnet; ComponentLink1URL: https://datasheet.ciiva.com/11201/ds30036-11201778.pdf?src-supplier=Avnet; ComponentLink2Description: https://datasheet.ciiva.com/15138/getdatasheetpartid-53265-15138780.pdf?src-supplier=Verical; ComponentLink2URL: https://datasheet.ciiva.com/15138/getdatasheetpartid-53265-15138780.pdf?src-supplier=Verical; ComponentLink3Description: https://datasheet.ciiva.com/22711/1915516-22711750.pdf?src-supplier=Element14; ComponentLink3URL: https://datasheet.ciiva.com/22711/1915516-22711750.pdf?src-supplier=Element14; ComponentLink4Description: https://datasheet.ciiva.com/11198/ds30036-11198999.pdf?src-supplier=Avnet; ComponentLink4URL: https://datasheet.ciiva.com/11198/ds30036-11198999.pdf?src-supplier=Avnet; ComponentLink5Description: https://datasheet.ciiva.com/6361/ds30036-6361256.pdf?src-supplier=Digi-Key; ComponentLink5URL: https://datasheet.ciiva.com/6361/ds30036-6361256.pdf?src-supplier=Digi-Key; ComponentLink6Description: https://datasheet.ciiva.com/6361/ds30036-6361254.pdf?src-supplier=Digi-Key; ComponentLink6URL: https://datasheet.ciiva.com/6361/ds30036-6361254.pdf?src-supplier=Digi-Key; Contact Plating: Tin,Matte; Frequency: 300MHz; Gain Bandwidth Product: 300MHz; Height: 1mm; Lead Free: Lead Free; Length: 3.05mm; Library Name: Udemy_ADAK_Library1.IntLib; Manufacturer Part Number: MMBT3904-7-F; Max Breakdown Voltage: 40V; Max Collector Current: 200mA; Max Frequency: 300MHz; Max Operating Temperature: 150°C; Min Operating Temperature: -55°C; Mount: Surface Mount; Number of Pins: 3; Package Quantity: 3000; Packaging: Tape and Reel; Polarity: NPN; Pricing 1: 1=0,13000, 10=0,11700, 100=0,06370, 500=0,03918, 1000=0,02671 (USD); Radiation Hardening: No; Reach SVHC Compliant: Yes; RoHS: Yes; RoHS Compliant: Yes; Series: Automotive, AEC-Q101; Stock 1: 7229342; Supplier 1: Digi-Key; Supplier Part Number 1: MMBT3904-FDICT-ND; Transition Frequency: 300MHz; Voltage Rating (DC): 40V; Weight: 0.000282oz; Width: 1.4mm
R1 R2 = RES 10K OHM 0603: |RES 10KΩ 1% 0603 SMD; Manufacturer: Yageo; Designed by: ADAK; Max Power Dissipation: 100mW; Temperature Coefficient: 100ppm/°C; Voltage Rating: 75V; Voltage Rating (DC): 50V; Case Code (Metric): 1608; Case Code (Imperial): 0603; Case/Package: 0603; Category: Chip SMD Resistors; Component Kind: Standard; ComponentLink1Description: https://datasheet.ciiva.com/7660/38590-7660789.pdf?src-supplier=Element14; ComponentLink1URL: https://datasheet.ciiva.com/7660/38590-7660789.pdf?src-supplier=Element14; ComponentLink2Description: https://datasheet.ciiva.com/7983/443971-7983596.pdf?src-supplier=Element14; ComponentLink2URL: https://datasheet.ciiva.com/7983/443971-7983596.pdf?src-supplier=Element14; ComponentLink3Description: https://datasheet.ciiva.com/22747/443971-22747519.pdf?src-supplier=Element14; ComponentLink3URL: https://datasheet.ciiva.com/22747/443971-22747519.pdf?src-supplier=Element14; ComponentLink4Description: https://datasheet.ciiva.com/46440/1868787-46440891.pdf?src-supplier=Element14; ComponentLink4URL: https://datasheet.ciiva.com/46440/1868787-46440891.pdf?src-supplier=Element14; ComponentLink5Description: https://datasheet.ciiva.com/7746/1738695-7746239.pdf?src-supplier=Element14; ComponentLink5URL: https://datasheet.ciiva.com/7746/1738695-7746239.pdf?src-supplier=Element14; ComponentLink6Description: https://datasheet.ciiva.com/15189/getdatasheetpartid-409289-15189591.pdf?src-supplier=Verical; ComponentLink6URL: https://datasheet.ciiva.com/15189/getdatasheetpartid-409289-15189591.pdf?src-supplier=Verical; Construction: Rectangular; Depth: 0.8mm; Features: Moisture Resistant; Height: 0.45mm; Lead Free: Lead Free; Lead Pitch: 0.3mm; Length: 1.6mm; Library Name: Udemy_ADAK_Library1.IntLib; Manufacturer Part Number: RC0603FR-0710KL; Max Operating Temperature: 155°C; Military Standard: Not; Min Operating Temperature: -55°C; Number of Pins: 2; Number of Terminations: 2; Packaging: Tape and Reel; Power Rating: 100mW; Pricing 1: 1=0,10000, 10=0,01900, 100=0,00770, 1000=0,00345, 2500=0,00300 (USD); Reach SVHC Compliant: Yes; Resistance Tolerance: 1%; Resistor Type: Thick Film; RoHS: Yes; RoHS Compliant: Yes; Series: RC; Size Code: 0603; Stock 1: 37352246; Supplier 1: Digi-Key; Supplier Part Number 1: 311-10.0KHRCT-ND; Termination: SMD/SMT; Tolerance: 1%; Weight: 7.1E-05oz; Width: 0.8mm
R3 R4 = RES 1K OHM 0603: |RES 1KΩ 1% 0603 SMD; Manufacturer: Yageo; Designed by: ADAK; Max Power Dissipation: 100mW; Temperature Coefficient: 100ppm/°C; Voltage Rating: 50V; Case Code (Metric): 1608; Case Code (Imperial): 0603; Case/Package: 0603; Category: Chip SMD Resistors; Component Kind: Standard; Construction: Rectangular; Contact Plating: Tin,Matte; Depth: 0.8mm; Features: Moisture Resistant; Height: 0.45mm; Lead Free: Lead Free; Length: 1.6mm; Library Name: Udemy_ADAK_Library1.IntLib; Manufacturer Part Number: RC0603FR-071KL; Max Operating Temperature: 155°C; Military Standard: Not; Min Operating Temperature: -55°C; Number of Terminations: 2; Packaging: Tape and Reel; Power Rating: 100mW; Pricing 1: 1=0,10000, 10=0,01900, 100=0,00770, 1000=0,00345, 2500=0,00300 (USD); Radiation Hardening: No; Reach SVHC Compliant: Yes; Resistance Tolerance: 1%; Resistor Type: Thick Film; RoHS: Yes; RoHS Compliant: Yes; Series: RC; Size Code: 0603; Stock 1: 27865761; Supplier 1: Digi-Key; Supplier Part Number 1: 311-1.00KHRCT-ND; Technology: THICK FILM; Termination: SMD/SMT; Tolerance: 1%
R5 = 5WD27: |RES 0.27Ω 5%  AXIAL; Manufacturer: NTE Electronics, Inc; Designed by: ADAK; Power(Watts): 5W; Component Kind: Standard; Library Name: Udemy_ADAK_Library1.IntLib; Operating Temperature: -55°C ~ 155°C; Package/Case: AXIAL
RL1 RL2 = ALQ112: |General Purpose Relay SPDT (1 Form C) 12VDC Coil Through Hole; Manufacturer: Panasonic; Coil Current: 33.3mA; Coil Power: 400mW; Coil Resistance: 360R; Coil Type: Non-Latching; Coil Voltage (DC): 12V; Contact Current Rating: 10A; Designed by: ADAK; Max Voltage Rating (AC): 250V; Operate Time: 20ms; Case/Package: ALQ112; Category: Power Relays; Component Kind: Standard; ComponentLink1Description: https://datasheet.ciiva.com/24388/307ds-61b20-en-lq-24388909.pdf?src-supplier=Onlinecomponents.com; ComponentLink1URL: https://datasheet.ciiva.com/24388/307ds-61b20-en-lq-24388909.pdf?src-supplier=Onlinecomponents.com; Contact Material: Silver; Depth: 10mm; Height: 16mm; Lead Free: Lead Free; Length: 20mm; Library Name: Udemy_ADAK_Library1.IntLib; Manufacturer Part Number: ALQ112; Max Operating Temperature: 85°C; Min Operating Temperature: -40°C; Number of Pins: 5; Package Quantity: 100; Packaging: Carton; Power Consumption: 400mW; Power Rating: 400mW; Pricing 1: 1=1,42000, 10=1,33600, 25=1,18720, 50=1,12780, 100=1,06850, 250=0,94976, 500=0,89040, 1000=0,83104, 5000=0,80136 (USD); Relay Type: General Purpose; Release Time: 20ms; RoHS: Yes; RoHS Compliant: Yes; Series: ALQ; Stock 1: 89301; Supplier 1: Digi-Key; Supplier Part Number 1: 255-3562-ND; Termination: PC Pin; Throw Configuration: SPDT; Voltage Rating (AC): 250V; Weight: 7g; Width: 10mm
T1 = BV302S12010-ZU: |Trafo 230V 1VA Through Hole; Current-Output(Max): 83mA; Designed by: ADAK; Voltage-Secondary: 12V; Windings: Both Single; Case/Package: BV302S; Component Kind: Standard; ComponentLink1Description: Datasheet; ComponentLink1URL: https://www.zettlermagnetics.com/pdfs/EI30_1.pdf; Library Name: Udemy_ADAK_Library1.IntLib; Mounting Type: Through Hole; Voltage-Primary: 230V
U1 = AP7361-33E-13: |Linear Voltage Regulator IC Positive Fixed 1 Output 1A SOT-223-3; Manufacturer: Diodes; Accuracy: 1%; Designed by: ADAK; Dropout Voltage: 500mV; Max Power Dissipation: 1.1W; Max Input Voltage: 6V; Min Current Limit: 1.1A; Min Input Voltage: 2.2V; Number of Outputs: 1; Output Voltage: 3.3V; Power Dissipation: 1.1kW; Power Supply Rejection Ratio (PSRR): 65dB; Quiescent Current: 70uA; Reference Voltage: 800mV; Case/Package: SOT-223; Category: Voltage Regulators - Linear; Component Kind: Standard; ComponentLink1Description: https://datasheet.ciiva.com/26926/getdatasheetpartid-670786-26926141.pdf?src-supplier=Verical; ComponentLink1URL: https://datasheet.ciiva.com/26926/getdatasheetpartid-670786-26926141.pdf?src-supplier=Verical; ComponentLink2Description: https://datasheet.ciiva.com/25651/ap7361-25651049.pdf?src-supplier=Digi-Key; ComponentLink2URL: https://datasheet.ciiva.com/25651/ap7361-25651049.pdf?src-supplier=Digi-Key; ComponentLink3Description: https://datasheet.ciiva.com/29568/ap7361-29568378.pdf?src-supplier=Digi-Key; ComponentLink3URL: https://datasheet.ciiva.com/29568/ap7361-29568378.pdf?src-supplier=Digi-Key; ComponentLink4Description: https://datasheet.ciiva.com/29568/ap7361-29568379.pdf?src-supplier=Digi-Key; ComponentLink4URL: https://datasheet.ciiva.com/29568/ap7361-29568379.pdf?src-supplier=Digi-Key; Contact Plating: Tin,Matte; Lead Free: Lead Free; Library Name: Udemy_ADAK_Library1.IntLib; Manufacturer Part Number: AP7361-33E-13; Max Operating Temperature: 85°C; Max Output Current: 1A; Min Operating Temperature: -40°C; Mount: Surface Mount; Number of Pins: 4; Number of Regulators: 1; Output Current: 1A; Output Type: Fixed; Package Quantity: 1; Packaging: Tape and Reel; Polarity: Positive; Pricing 1: 5=0,61100, 10=0,52600, 100=0,36400, 500=0,30900 (EUR); Radiation Hardening: No; Reach SVHC Compliant: Yes; RoHS: Yes; RoHS Compliant: Yes; Series: -; Stock 1: 1910; Supplier 1: Farnell; Supplier Part Number 1: 3482976; Weight: 0.000282oz
U2 = LM2675MX-12/NOPB: |Regulator IC Positive Fixed 12V 1 Output 1A 8-SOIC (0.154", 3.90mm Width); Manufacturer: TI National Semiconductor; Designed by: ADAK; Efficiency: 94%; Max Input Voltage: 40V; Min Input Voltage: 8V; Number of Outputs: 1; Quiescent Current: 2.5mA; Switching Frequency: 260kHz; Synchronous Rectifier: No; Case/Package: SOIC N; Category: Voltage Regulators - Switching; Component Kind: Standard; ComponentLink1Description: https://datasheet.ciiva.com/41457/001013881-da-01-en-ic-reg-buck-lm2675mx-12-nopb-soic-8-tid-41457896.pdf?src-supplier=Conrad; ComponentLink1URL: https://datasheet.ciiva.com/41457/001013881-da-01-en-ic-reg-buck-lm2675mx-12-nopb-soic-8-tid-41457896.pdf?src-supplier=Conrad; ComponentLink2Description: https://datasheet.ciiva.com/3220/lm2675-3220840.pdf?src-supplier=Avnet; ComponentLink2URL: https://datasheet.ciiva.com/3220/lm2675-3220840.pdf?src-supplier=Avnet; Contact Plating: Tin; Lead Free: Lead Free; Library Name: Udemy_ADAK_Library1.IntLib; Manufacturer Part Number: LM2675MX-12/NOPB; Max Operating Temperature: 125°C; Max Output Current: 1A; Min Operating Temperature: -40°C; Mount: Surface Mount; Number of Pins: 8; Operating Supply Voltage: 40V; Output Current: 1A; Output Type: Fixed; Output Voltage: 12V; Package Quantity: 2500; Packaging: Tape and Reel; Pricing 1: 1=4,94000, 10=4,44000, 25=4,19760, 100=3,63790, 250=3,45136, 500=3,09690, 1000=2,64000 (USD); Radiation Hardening: No; RoHS: Yes; RoHS Compliant: Yes; Series: LM2675; Supplier 1: Digi-Key; Supplier Part Number 1: 296-35410-1-ND
USB1 = Micro B USB Connector: |USB - micro B USB 2.0 Receptacle Connector 5 Position Surface Mount, Right Angle; Manufacturer: Amphenol ICC / FCI; Designed by: ADAK; Category: USB Connectors; Component Kind: Standard; ComponentLink1Description: http://uk.rs-online.com/webdocs/1450/0900766b814507ee.pdf?src-supplier=Rs; ComponentLink1URL: http://uk.rs-online.com/webdocs/1450/0900766b814507ee.pdf?src-supplier=Rs; ComponentLink2Description: http://www.amphenol-icc.com/media/wysiwyg/files/drawing/10118192.pdf?src-supplier=Digi-Key; ComponentLink2URL: http://www.amphenol-icc.com/media/wysiwyg/files/drawing/10118192.pdf?src-supplier=Digi-Key; ComponentLink3Description: https://cdn.amphenol-icc.com/media/wysiwyg/files/documentation/datasheet/inputoutput/io_usb_micro.pdf?src-supplier=Arrow+Electronics; ComponentLink3URL: https://cdn.amphenol-icc.com/media/wysiwyg/files/documentation/datasheet/inputoutput/io_usb_micro.pdf?src-supplier=Arrow+Electronics; ComponentLink4Description: https://cdn.amphenol-icc.com/media/wysiwyg/files/documentation/datasheet/inputoutput/io_usb_micro.pdf?src-supplier=Avnet; ComponentLink4URL: https://cdn.amphenol-icc.com/media/wysiwyg/files/documentation/datasheet/inputoutput/io_usb_micro.pdf?src-supplier=Avnet; ComponentLink5Description: http://www.farnell.com/cad/1935767.pdf?src-supplier=Element14; ComponentLink5URL: http://www.farnell.com/cad/1935767.pdf?src-supplier=Element14; ComponentLink6Description: https://cdn.amphenol-icc.com/media/wysiwyg/files/documentation/datasheet/inputoutput/io_usb_micro.pdf?src-supplier=Allied+Electronics; ComponentLink6URL: https://cdn.amphenol-icc.com/media/wysiwyg/files/documentation/datasheet/inputoutput/io_usb_micro.pdf?src-supplier=Allied+Electronics; ComponentLink7Description: https://cdn.amphenol-icc.com/media/wysiwyg/files/documentation/datasheet/inputoutput/io_usb_micro.pdf?src-supplier=Mouser; ComponentLink7URL: https://cdn.amphenol-icc.com/media/wysiwyg/files/documentation/datasheet/inputoutput/io_usb_micro.pdf?src-supplier=Mouser; ComponentLink8Description: https://cdn.amphenol-icc.com/media/wysiwyg/files/documentation/datasheet/inputoutput/io_usb_micro.pdf?src-supplier=Verical; ComponentLink8URL: https://cdn.amphenol-icc.com/media/wysiwyg/files/documentation/datasheet/inputoutput/io_usb_micro.pdf?src-supplier=Verical; ComponentLink9Description: http://www.farnell.com/datasheets/2366786.pdf?src-supplier=Newark; ComponentLink9URL: http://www.farnell.com/datasheets/2366786.pdf?src-supplier=Newark; ComponentLink10Description: http://www.farnell.com/datasheets/2366786.pdf?src-supplier=Farnell; ComponentLink10URL: http://www.farnell.com/datasheets/2366786.pdf?src-supplier=Farnell; ComponentLink11Description: https://cdn.amphenol-icc.com/media/wysiwyg/files/documentation/datasheet/inputoutput/io_usb_micro.pdf?src-supplier=NAC+Semi; ComponentLink11URL: https://cdn.amphenol-icc.com/media/wysiwyg/files/documentation/datasheet/inputoutput/io_usb_micro.pdf?src-supplier=NAC+Semi; ComponentLink12Description: https://cdn.amphenol-icc.com/media/wysiwyg/files/documentation/datasheet/inputoutput/io_usb_micro.pdf?src-supplier=Freelance+Electronics; ComponentLink12URL: https://cdn.amphenol-icc.com/media/wysiwyg/files/documentation/datasheet/inputoutput/io_usb_micro.pdf?src-supplier=Freelance+Electronics; ComponentLink13Description: https://cdn.amphenol-icc.com/media/wysiwyg/files/documentation/datasheet/inputoutput/io_usb_micro.pdf?src-supplier=Heilind+Electronics; ComponentLink13URL: https://cdn.amphenol-icc.com/media/wysiwyg/files/documentation/datasheet/inputoutput/io_usb_micro.pdf?src-supplier=Heilind+Electronics; ComponentLink14Description: https://cdn.amphenol-icc.com/media/wysiwyg/files/documentation/datasheet/inputoutput/io_usb_micro.pdf?src-supplier=TME; ComponentLink14URL: https://cdn.amphenol-icc.com/media/wysiwyg/files/documentation/datasheet/inputoutput/io_usb_micro.pdf?src-supplier=TME; ComponentLink15Description: https://cdn.amphenol-icc.com/media/wysiwyg/files/documentation/datasheet/inputoutput/io_usb_micro.pdf?src-supplier=TTI; ComponentLink15URL: https://cdn.amphenol-icc.com/media/wysiwyg/files/documentation/datasheet/inputoutput/io_usb_micro.pdf?src-supplier=TTI; ComponentLink16Description: https://cdn.amphenol-icc.com/media/wysiwyg/files/documentation/datasheet/inputoutput/io_usb_micro.pdf?src-supplier=SOS+electronic; ComponentLink16URL: https://cdn.amphenol-icc.com/media/wysiwyg/files/documentation/datasheet/inputoutput/io_usb_micro.pdf?src-supplier=SOS+electronic; Library Name: Udemy_ADAK_Library1.IntLib; Manufacturer Part Number: 10118192-0001LF; Pricing 1: 1400=0,239140, 2800=0,217400, 7000=0,210160, 9800=0,195660, 14000=0,192760, 35000=0,188420 (USD); RoHS: No; Stock 1: 152600; Supplier 1: Digi-Key; Supplier Part Number 1: 609-4613-2-ND
